# Altium schematic sheet AwesomeRemote.SchDoc
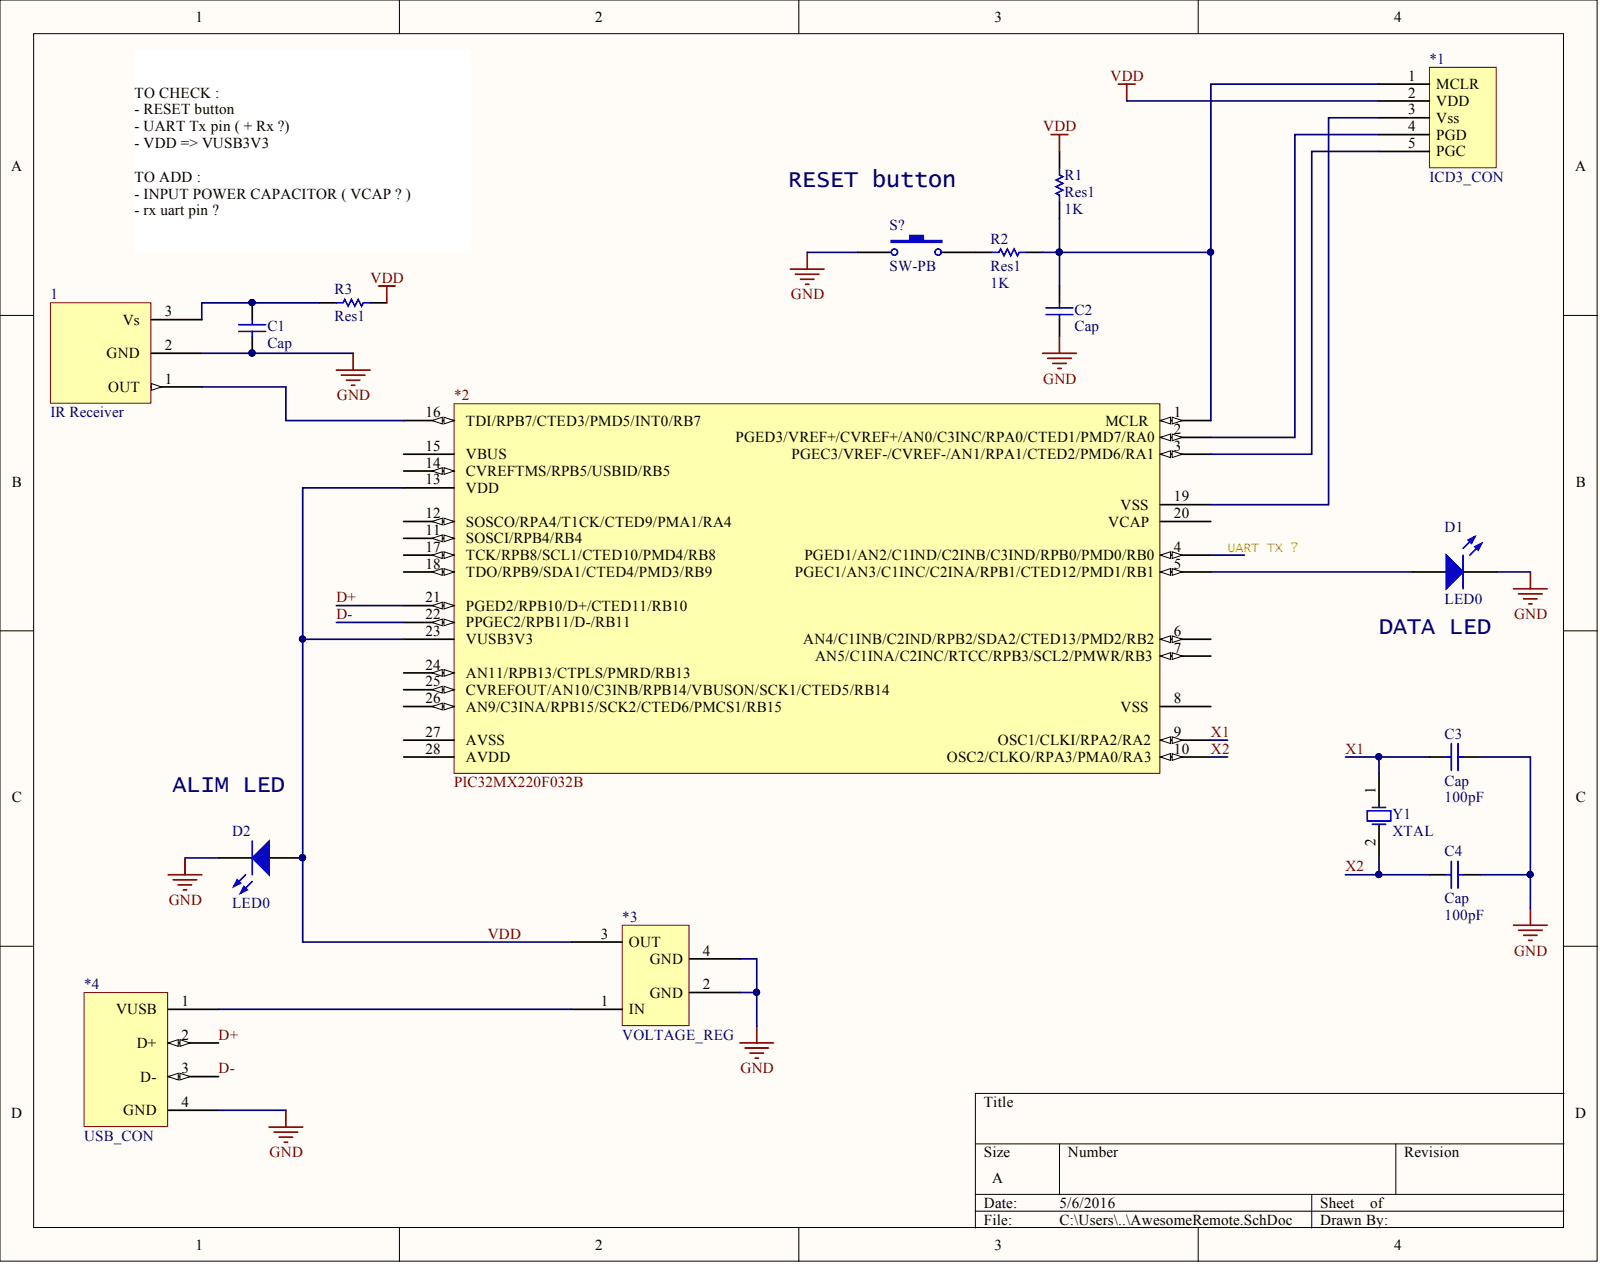
[diagram p0: AwesomeRemote.SchDoc]

## Parts
1  IR Receiver
*1  ICD3_CON
*2  PIC32MX220F032B  (value not drawn)
*3  VOLTAGE_REG
*4  USB_CON
C1  Cap  [RAD-0.3]
C2  Cap  [RAD-0.3]
C3  Cap  value 100pF  [RAD-0.3]
C4  Cap  value 100pF  [RAD-0.3]
D1  LED0  [LED-0]
D2  LED0  [LED-0]
R1  Res1  value 1K  [AXIAL-0.3]
R2  Res1  value 1K  [AXIAL-0.3]
R3  Res1  [AXIAL-0.3]
S?  SW-PB  [SPST-2]
Y1  XTAL  [R38]

## Nets
GND: 1.2 *3.2(GND) *3.4(GND) *4.4(GND) C1.1 C2.1 C3.2 C4.2 D1.2 D2.2 S?.1
D+: *2.21(PGED2/RPB10/D+/CTED11/RB10) *4.2(D+)
D-: *2.22(PPGEC2/RPB11/D-/RB11) *4.3(D-)
VDD: *1.2(VDD) *2.13(VDD) *2.23(VUSB3V3) *3.3(OUT) D2.1 R1.2 R3.2
X1: *2.9(OSC1/CLKI/RPA2/RA2) C3.1 Y1.1
X2: *2.10(OSC2/CLKO/RPA3/PMA0/RA3) C4.1 Y1.2
Net1_3: 1.3 C1.2 R3.1
Net*1_1: *1.1(MCLR) *2.1(MCLR) C2.2 R1.1 R2.2
Net*1_3: *1.3(Vss) *2.19(VSS)
Net*1_4: *1.4(PGD) *2.2(PGED3/VREF+/CVREF+/AN0/C3INC/RPA0/CTED1/PMD7/RA0)
Net*1_5: *1.5(PGC) *2.3(PGEC3/VREF-/CVREF-/AN1/RPA1/CTED2/PMD6/RA1)
Net*2_5: *2.5(PGEC1/AN3/C1INC/C2INA/RPB1/CTED12/PMD1/RB1) D1.1
Net*2_16: 1.1 *2.16(TDI/RPB7/CTED3/PMD5/INT0/RB7)
Net*3_1: *3.1(IN) *4.1(VUSB)
NetR2_1: R2.1 S?.2

Not connected: *2 4(PGED1/AN2/C1IND/C2INB/C3IND/RPB0/PMD0/RB0) 6(AN4/C1INB/C2IND/RPB2/SDA2/CTED13/PMD2/RB2) 7(AN5/C1INA/C2INC/RTCC/RPB3/SCL2/PMWR/RB3) 8(VSS) 11(SOSCI/RPB4/RB4) 12(SOSCO/RPA4/T1CK/CTED9/PMA1/RA4) 14(CVREFTMS/RPB5/USBID/RB5) 15(VBUS) 17(TCK/RPB8/SCL1/CTED10/PMD4/RB8) 18(TDO/RPB9/SDA1/CTED4/PMD3/RB9) 20(VCAP) 24(AN11/RPB13/CTPLS/PMRD/RB13) 25(CVREFOUT/AN10/C3INB/RPB14/VBUSON/SCK1/CTED5/RB14) 26(AN9/C3INA/RPB15/SCK2/CTED6/PMCS1/RB15) 27(AVSS) 28(AVDD)

## Library data of the parts
1 = TSOP38238: Fabricant: Vishay; Fournisseur: Farnell; RefFournisseur: 2251359; Component Kind: Standard; Description: IR Receiver; RefFabricant: TSOP38238; Library Name: AwesomeComponents.SchLib
*4 = USB_CON: USB_fem_2.0; Fabricant: SAMTEC; Fournisseur: Farnell; RefFabricant: USBR‐B‐S‐S‐O‐TH; RefFournisseur: 1753809; Component Kind: Standard; datash: http://www.farnell.com/datasheets/1699406.pdf; Library Name: AwesomeComponents.SchLib
C1 C2 = Cap: Capacitor; Value: 100pF; Component Kind: Standard; LatestRevisionDate: 17-Jul-2002; LatestRevisionNote: Re-released for DXP Platform.; Library Name: Miscellaneous Devices.IntLib; PackageDescription: Radial Cap, Thru-Hole; 2 Leads; 0.3 in Pin Spacing; PackageReference: RAD-0.3; Published: 8-Jun-2000; Signal Integrity: Cap; Simulation: CAP; Publisher: Altium Limited
C3 C4 = Cap: Capacitor; Component Kind: Standard; LatestRevisionDate: 17-Jul-2002; LatestRevisionNote: Re-released for DXP Platform.; Library Name: Miscellaneous Devices.IntLib; PackageDescription: Radial Cap, Thru-Hole; 2 Leads; 0.3 in Pin Spacing; PackageReference: RAD-0.3; Published: 8-Jun-2000; Signal Integrity: Cap; Simulation: CAP; Publisher: Altium Limited
D1 D2 = LED0: Typical INFRARED GaAs LED; Component Kind: Standard; LatestRevisionDate: 08-Jul-2005; LatestRevisionNote: Mech Layer 1 removed.; Library Name: Miscellaneous Devices.IntLib; PackageDescription: LED; 2 Leads; PackageReference: LED-0; Published: 8-Jun-2000; Simulation: LED0; Publisher: Altium Limited
R1 R2 = Res1: Resistor; Component Kind: Standard; LatestRevisionDate: 17-Jul-2002; LatestRevisionNote: Re-released for DXP Platform.; Library Name: Miscellaneous Devices.IntLib; PackageDescription: Axial Device, Thru-Hole; 2 Leads; 0.3 in Pin Spacing; PackageReference: AXIAL-0.3; Published: 8-Jun-2000; Signal Integrity: Res; Simulation: RESISTOR; Publisher: Altium Limited
R3 = Res1: Resistor; Value: 1K; Component Kind: Standard; LatestRevisionDate: 17-Jul-2002; LatestRevisionNote: Re-released for DXP Platform.; Library Name: Miscellaneous Devices.IntLib; PackageDescription: Axial Device, Thru-Hole; 2 Leads; 0.3 in Pin Spacing; PackageReference: AXIAL-0.3; Published: 8-Jun-2000; Signal Integrity: Res; Simulation: RESISTOR; Publisher: Altium Limited
S? = SW-PB: Switch; Component Kind: Standard; LatestRevisionDate: 17-Jul-2002; LatestRevisionNote: Re-released for DXP Platform.; Library Name: Miscellaneous Devices.IntLib; PackageDescription: Switch; 2 Leads; PackageReference: SPST-2; Published: 24-Mar-1999; Publisher: Altium Limited
Y1 = XTAL: Crystal Oscillator; Component Kind: Standard; LatestRevisionDate: 17-Jul-2002; LatestRevisionNote: Re-released for DXP Platform.; Library Name: Miscellaneous Devices.IntLib; PackageDescription: Crystal, Thru-Hole; 2 Leads; Body 3.1 x 8.2 mm (Dia.xH); PackageReference: R38; Published: 8-Jun-2000; Simulation: XTAL; Publisher: Altium Limited
*1 = ICD3_CON: Component Kind: Standard; Library Name: AwesomeComponents.SchLib
*2 = PIC32MX220F032B: Component Kind: Standard; Library Name: AwesomeComponents.SchLib
*3 = VOLTAGE_REG: Component Kind: Standard; Library Name: AwesomeComponents.SchLib
